# Altium schematic sheet aksv3.1.SchDoc
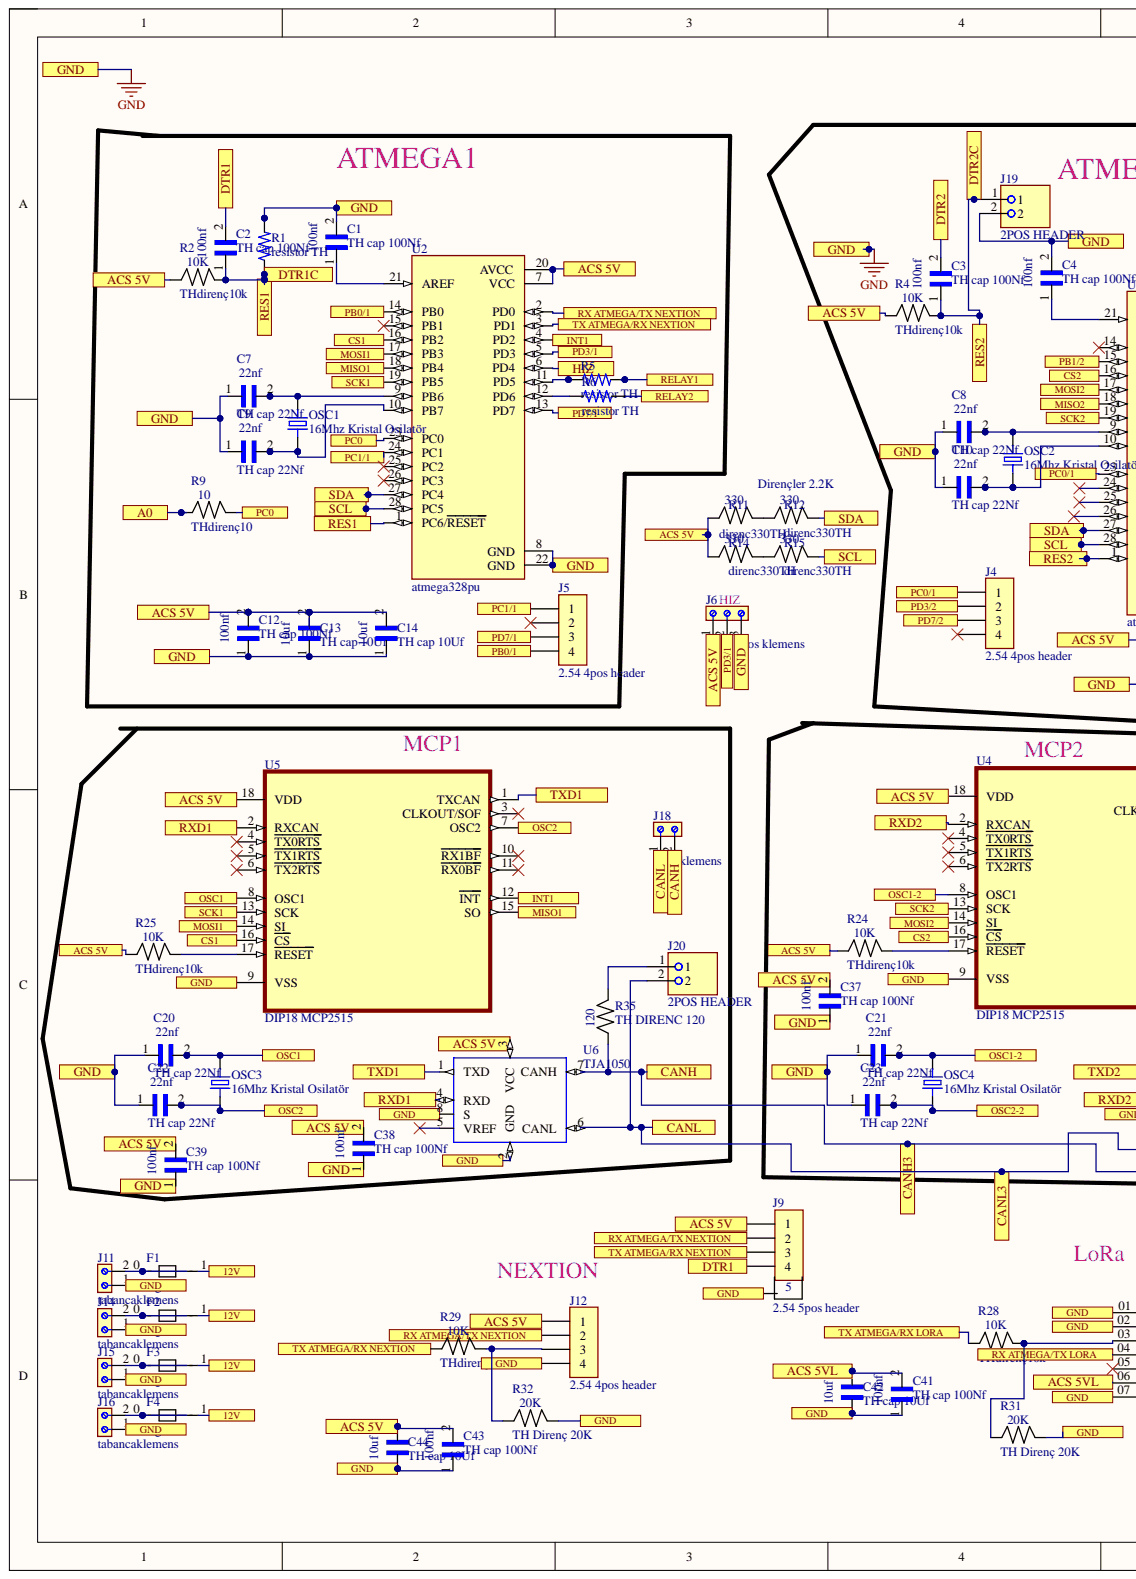
[diagram p0: aksv3.1.SchDoc, left]
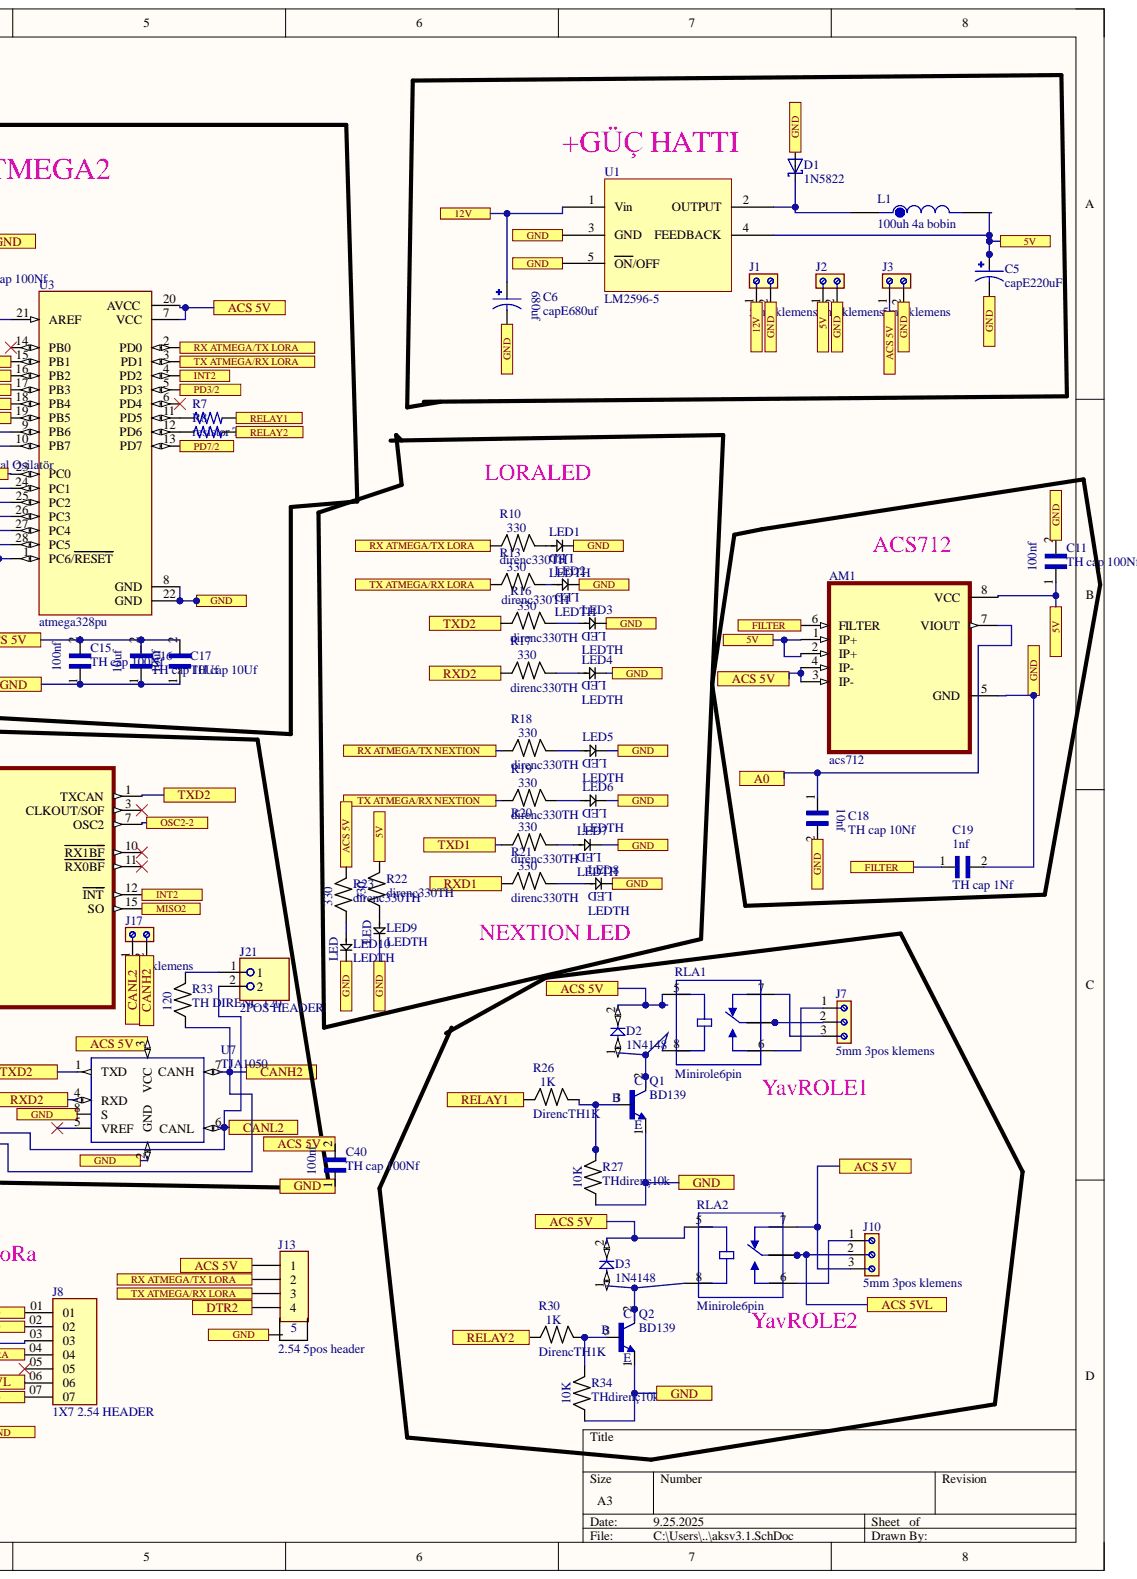
[diagram p1: aksv3.1.SchDoc, right]

## Parts
AM1  acs712  [SOIC-8 acs712]
C1  TH cap 100Nf  [Kapkucuk]
C2  TH cap 100Nf  [Kapkucuk]
C3  TH cap 100Nf  [Kapkucuk]
C4  TH cap 100Nf  [Kapkucuk]
C5  capE220uF  [elektrolitkondansatör]
C6  capE680uf  [elektrolitkondansatör]
C7  TH cap 22Nf  [Kapkucuk]
C8  TH cap 22Nf  [Kapkucuk]
C9  TH cap 22Nf  [Kapkucuk]
C10  TH cap 22Nf  [Kapkucuk]
C11  TH cap 100Nf  [Kapkucuk]
C12  TH cap 100Nf  [Kapkucuk]
C13  TH cap 10Uf  [Kapkucuk]
C14  TH cap 10Uf  [Kapkucuk]
C15  TH cap 100Nf  [Kapkucuk]
C16  TH cap 10Uf  [Kapkucuk]
C17  TH cap 10Uf  [Kapkucuk]
C18  TH cap 10Nf  [Kapkucuk]
C19  TH cap 1Nf  [Kapkucuk]
C20  TH cap 22Nf  [Kapkucuk]
C21  TH cap 22Nf  [Kapkucuk]
C22  TH cap 22Nf  [Kapkucuk]
C23  TH cap 22Nf  [Kapkucuk]
C37  TH cap 100Nf  [Kapkucuk]
C38  TH cap 100Nf  [Kapkucuk]
C39  TH cap 100Nf  [Kapkucuk]
C40  TH cap 100Nf  [Kapkucuk]
C41  TH cap 100Nf  [Kapkucuk]
C42  TH cap 10Uf  [Kapkucuk]
C43  TH cap 100Nf  [Kapkucuk]
C44  TH cap 10Uf  [Kapkucuk]
D1  1N5822  [do-201 diode]
D2  1N4148  [1N4148]
D3  1N4148  [1N4148]
F1  sigorta  [sigorta]
F2  sigorta  [sigorta]
F3  sigorta  [sigorta]
F4  sigorta  [sigorta]
J1  5mmklemens  [5mmklemens]
J2  5mmklemens  [5mmklemens]
J3  5mmklemens  [5mmklemens]
J4  2.54 4pos header  [2.54 4pos header]
J5  2.54 4pos header  [2.54 4pos header]
J6  5mm 3pos klemens  [5mm 3pos klemens]
J7  5mm 3pos klemens  [5mm 3pos klemens]
J8  1X7 2.54 HEADER  [1X7 2.54 HEADER]
J9  2.54 5pos header  [2.54 5pos header]
J10  5mm 3pos klemens  [5mm 3pos klemens]
J11  tabancaklemens  [3.81mm Kemens]
J12  2.54 4pos header  [2.54 4pos header]
J13  2.54 5pos header  [2.54 5pos header]
J14  tabancaklemens  [3.81mm Kemens]
J15  tabancaklemens  [3.81mm Kemens]
J16  tabancaklemens  [3.81mm Kemens]
J17  5mmklemens  [5mmklemens]
J18  5mmklemens  [5mmklemens]
J19  2POS HEADER  [1X2 HEADER]
J20  2POS HEADER  [1X2 HEADER]
J21  2POS HEADER  [1X2 HEADER]
L1  100uh 4a bobin  [bobin]
LED1  LEDTH  [led3mm]
LED2  LEDTH  [led3mm]
LED3  LEDTH  [led3mm]
LED4  LEDTH  [led3mm]
LED5  LEDTH  [led3mm]
LED6  LEDTH  [led3mm]
LED7  LEDTH  [led3mm]
LED8  LEDTH  [led3mm]
LED9  LEDTH  [led3mm]
LED10  LEDTH  [led3mm]
OSC1  16Mhz Kristal Osilatör  [16Mhz Kristal Osilatör]
OSC2  16Mhz Kristal Osilatör  [16Mhz Kristal Osilatör]
OSC3  16Mhz Kristal Osilatör  [16Mhz Kristal Osilatör]
OSC4  16Mhz Kristal Osilatör  [16Mhz Kristal Osilatör]
Q1  BD139  [BD139]
Q2  BD139  [BD139]
R1  resistor TH  [resistor TH]
R2  THdirenç10k  [resistor TH]
R4  THdirenç10k  [resistor TH]
R5  resistor TH  [resistor TH]
R6  resistor TH  [resistor TH]
R7  resistor TH  [resistor TH]
R8  resistor TH  [resistor TH]
R9  THdirenç10  [resistor TH]
R10  direnc330TH  [resistor TH]
R11  direnc330TH  [resistor TH]
R12  direnc330TH  [resistor TH]
R13  direnc330TH  [resistor TH]
R14  direnc330TH  [resistor TH]
R15  direnc330TH  [resistor TH]
R16  direnc330TH  [resistor TH]
R17  direnc330TH  [resistor TH]
R18  direnc330TH  [resistor TH]
R19  direnc330TH  [resistor TH]
R20  direnc330TH  [resistor TH]
R21  direnc330TH  [resistor TH]
R22  direnc330TH  [resistor TH]
R23  direnc330TH  [resistor TH]
R24  THdirenç10k  [resistor TH]
R25  THdirenç10k  [resistor TH]
R26  DirencTH1K  [resistor TH]
R27  THdirenç10k  [resistor TH]
R28  THdirenç10k  [resistor TH]
R29  THdirenç10k  [resistor TH]
R30  DirencTH1K  [resistor TH]
R31  TH Direnç 20K  [resistor TH]
R32  TH Direnç 20K  [resistor TH]
R33  TH DIRENC 120  [resistor TH]
R34  THdirenç10k  [resistor TH]
R35  TH DIRENC 120  [resistor TH]
RLA1  Minirole6pin  [minirole]
RLA2  Minirole6pin  [minirole]
U1  LM2596-5  [LM2596 SMD]
U2  atmega328pu  [atmega328pu]
U3  atmega328pu  [atmega328pu]
U4  DIP18 MCP2515  [DIP18 MCP2515]
U5  DIP18 MCP2515  [DIP18 MCP2515]
U6  TJA1050  [TJA1050]
U7  TJA1050  [TJA1050]

## Ports
5V, 12V, A0, ACS 5V, ACS 5VL, CANH, CANH2, CANH3, CANL, CANL2, CANL3, CS1, CS2, DTR1, DTR1C, DTR2, DTR2C, FILTER, GND, HIZ, INT1, INT2, MISO1, MISO2, MOSI1, MOSI2, OSC1, OSC1-2, OSC2, OSC2-2, PB0/1, PB1/2, PC0, PC0/1, PC1/1, PD3/1, PD3/2, PD7/1, PD7/2, RELAY1, RELAY2, RES1, RES2, RX ATMEGA/TX LORA, RX ATMEGA/TX NEXTION, RXD1, RXD2, SCK1, SCK2, SCL, SDA, TX ATMEGA/RX LORA, TX ATMEGA/RX NEXTION, TXD1, TXD2

## Nets
5V: AM1.1(IP+) AM1.2(IP+) AM1.8(VCC) C5.P C11.1 J2.1 L1.2 R22.1 U1.4(FEEDBACK) ; port 5V
12V: C6.P F1.1 F2.1 F3.1 F4.1 J1.1 U1.1(Vin) ; port 12V
A0: AM1.7(VIOUT) C18.1 R9.0 ; port A0
ACS 5V: AM1.3(IP-) AM1.4(IP-) C12.2 C13.2 C14.2 C15.2 C16.2 C17.2 C37.2 C38.2 C39.2 C40.2 C43.2 C44.2 D2.2 D3.2 J3.1 J6.1 J9.1 J10.3 J12.1 J13.1 R2.0 R4.0 R11.0 R14.0 R23.1 R24.0 R25.0 RLA1.5 RLA2.5 RLA2.7 U2.7(VCC) U2.20(AVCC) U3.7(VCC) U3.20(AVCC) U4.18(VDD) U5.18(VDD) U6.3(VCC) U7.3(VCC) ; port ACS 5V
ACS 5VL: C41.2 C42.2 J8.06 J10.2 RLA2.1 RLA2.12 ; port ACS 5VL
CANH: J17.2 J18.2 R33.0 R35.0 U6.7(CANH) U7.7(CANH) ; port CANH, port CANH2, port CANH3
CANL: J17.1 J18.1 J20.2 J21.2 U6.6(CANL) U7.6(CANL) ; port CANL, port CANL2, port CANL3
CS1: U2.16(PB2) U5.16(CS) ; port CS1
CS2: U3.16(PB2) U4.16(CS) ; port CS2
DTR1: C2.2 J9.4 ; port DTR1
DTR1C: C2.1 R1.0 R2.1 U2.1(PC6/RESET) ; port DTR1C, port RES1
DTR2: C3.2 J13.4 ; port DTR2
DTR2C: C3.1 J19.1 R4.1 U3.1(PC6/RESET) ; port DTR2C, port RES2
FILTER: AM1.6(FILTER) C19.1 ; port FILTER
GND: AM1.5(GND) C1.2 C4.2 C5.N C6.N C7.1 C8.1 C9.1 C10.1 C11.2 C12.1 C13.1 C14.1 C15.1 C16.1 C17.1 C18.2 C19.2 C20.1 C21.1 C22.1 C23.1 C37.1 C38.1 C39.1 C40.1 C41.1 C42.1 C43.1 C44.1 D1.A J1.2 J2.2 J3.2 J6.3 J8.01 J8.02 J8.07 J9.5 J11.1 J12.4 J13.5 J14.1 J15.1 J16.1 J19.2 LED1.1 LED2.1 LED3.1 LED4.1 LED5.1 LED6.1 LED7.1 LED8.1 LED9.1 LED10.1 Q1.1 Q2.1 R1.1 R27.0 R31.1 R32.1 R34.0 U1.3(GND) U1.5(ON/OFF) U2.8(GND) U2.22(GND) U3.8(GND) U3.22(GND) U4.9(VSS) U5.9(VSS) U6.2(GND) U6.8(S) U7.2(GND) U7.8(S) ; port GND
HIZ: U2.6(PD4) ; port HIZ
INT1: U2.4(PD2) U5.12(INT) ; port INT1
INT2: U3.4(PD2) U4.12(INT) ; port INT2
MISO1: U2.18(PB4) U5.15(SO) ; port MISO1
MISO2: U3.18(PB4) U4.15(SO) ; port MISO2
MOSI1: U2.17(PB3) U5.14(SI) ; port MOSI1
MOSI2: U3.17(PB3) U4.14(SI) ; port MOSI2
OSC1: C20.2 OSC3.2 U5.8(OSC1) ; port OSC1
OSC1-2: C21.2 OSC4.2 U4.8(OSC1) ; port OSC1-2
OSC2: C22.2 OSC3.1 U5.7(OSC2) ; port OSC2
OSC2-2: C23.2 OSC4.1 U4.7(OSC2) ; port OSC2-2
PB0/1: J5.4 U2.14(PB0) ; port PB0/1
PB1/2: U3.15(PB1) ; port PB1/2
PC0: R9.1 U2.23(PC0) ; port PC0
PC0/1: J4.1 U3.23(PC0) ; port PC0/1
PC1/1: J5.1 U2.24(PC1) ; port PC1/1
PD3/1: J6.2 U2.5(PD3) ; port PD3/1
PD3/2: J4.2 U3.5(PD3) ; port PD3/2
PD7/1: J5.3 U2.13(PD7) ; port PD7/1
PD7/2: J4.3 U3.13(PD7) ; port PD7/2
RELAY1: R5.1 R7.1 R26.0 ; port RELAY1
RELAY2: R6.1 R8.1 R30.0 ; port RELAY2
RX ATMEGA/TX LORA: J8.04 J13.2 R10.0 U3.2(PD0) ; port RX ATMEGA/TX LORA
RX ATMEGA/TX NEXTION: J9.2 J12.2 R18.0 U2.2(PD0) ; port RX ATMEGA/TX NEXTION
RXD1: R21.0 U5.2(RXCAN) U6.4(RXD) ; port RXD1
RXD2: R17.0 U4.2(RXCAN) U7.4(RXD) ; port RXD2
SCK1: U2.19(PB5) U5.13(SCK) ; port SCK1
SCK2: U3.19(PB5) U4.13(SCK) ; port SCK2
SCL: R15.1 U2.28(PC5) U3.28(PC5) ; port SCL
SDA: R12.1 U2.27(PC4) U3.27(PC4) ; port SDA
TX ATMEGA/RX LORA: J13.3 R13.0 R28.0 U3.3(PD1) ; port TX ATMEGA/RX LORA
TX ATMEGA/RX NEXTION: J9.3 R19.0 R29.0 U2.3(PD1) ; port TX ATMEGA/RX NEXTION
TXD1: R20.0 U5.1(TXCAN) U6.1(TXD) ; port TXD1
TXD2: R16.0 U4.1(TXCAN) U7.1(TXD) ; port TXD2
NetC1_1: C1.1 U2.21(AREF)
NetC4_1: C4.1 U3.21(AREF)
NetC7_2: C7.2 OSC1.2 U2.9(PB6)
NetC8_2: C8.2 OSC2.2 U3.9(PB6)
NetC9_2: C9.2 OSC1.1 U2.10(PB7)
NetC10_2: C10.2 OSC2.1 U3.10(PB7)
NetD1_C: D1.C L1.1 U1.2(OUTPUT)
NetD2_1: D2.1 Q1.2 RLA1.8
NetD3_1: D3.1 Q2.2 RLA2.8
NetF1_0: F1.0 J11.2
NetF2_0: F2.0 J14.2
NetF3_0: F3.0 J15.2
NetF4_0: F4.0 J16.2
NetJ7_1: J7.1 RLA1.6
NetJ7_2: J7.2 RLA1.1 RLA1.12
NetJ7_3: J7.3 RLA1.7
NetJ8_03: J8.03 R28.1 R31.0
NetJ10_1: J10.1 RLA2.6
NetJ12_3: J12.3 R29.1 R32.0
NetJ20_1: J20.1 R35.1
NetJ21_1: J21.1 R33.1
NetLED1_0: LED1.0 R10.1
NetLED2_0: LED2.0 R13.1
NetLED3_0: LED3.0 R16.1
NetLED4_0: LED4.0 R17.1
NetLED5_0: LED5.0 R18.1
NetLED6_0: LED6.0 R19.1
NetLED7_0: LED7.0 R20.1
NetLED8_0: LED8.0 R21.1
NetLED9_0: LED9.0 R22.0
NetLED10_0: LED10.0 R23.0
NetQ1_3: Q1.3 R26.1 R27.1
NetQ2_3: Q2.3 R30.1 R34.1
NetR5_0: R5.0 U2.11(PD5)
NetR6_0: R6.0 U2.12(PD6)
NetR7_0: R7.0 U3.11(PD5)
NetR8_0: R8.0 U3.12(PD6)
NetR11_1: R11.1 R12.0
NetR14_1: R14.1 R15.0
NetR24_1: R24.1 U4.17(RESET)
NetR25_1: R25.1 U5.17(RESET)

Not connected: J4 4; J5 2; J8 05; U2 15(PB1) 25(PC2) 26(PC3); U3 6(PD4) 14(PB0) 24(PC1) 25(PC2) 26(PC3); U4 3(CLKOUT/SOF) 4(TX0RTS) 5(TX1RTS) 6(TX2RTS) 10(RX1BF) 11(RX0BF); U5 3(CLKOUT/SOF) 4(TX0RTS) 5(TX1RTS) 6(TX2RTS) 10(RX1BF) 11(RX0BF); U6 5(VREF); U7 5(VREF)

## Library data of the parts
AM1 = acs712: Component Kind: Standard; Library Name: Copy of Parts.SchLib
C1-C4 C11 C12 C15 C37-C41 C43 = TH cap 100Nf: Component Kind: Standard; Library Name: Copy of Parts.SchLib
C5 = capE220uF: Component Kind: Standard; Library Name: Copy of Parts.SchLib
C6 = capE680uf: Component Kind: Standard; Library Name: Copy of Parts.SchLib
C7-C10 C20-C23 = TH cap 22nf: Component Kind: Standard; Library Name: Copy of Parts.SchLib
C13 C14 C16 C17 C42 C44 = TH cap 10Uf: Component Kind: Standard; Library Name: Copy of Parts.SchLib
C18 = TH cap 10Nf: Component Kind: Standard; Library Name: Copy of Parts.SchLib
C19 = TH cap 1Nf: Component Kind: Standard; Library Name: Copy of Parts.SchLib
D1 = 1N5822: Component Kind: Standard; Library Name: Copy of Parts.SchLib
D2 D3 = 1N4148: Component Kind: Standard; Library Name: Copy of Parts.SchLib
F1-F4 = sigorta: Component Kind: Standard; Library Name: Copy of Parts.SchLib
J1-J3 J17 J18 = 5mmklemens: Component Kind: Standard; Library Name: Copy of Parts.SchLib
J4 J5 J12 = 2.54 4pos header: Component Kind: Standard; Library Name: Copy of Parts.SchLib
J6 J7 J10 = 5mm 3pos klemens: Component Kind: Standard; Library Name: Copy of Parts.SchLib
J8 = 1X7 2.54 HEADER: Component Kind: Standard; Library Name: Copy of Parts.SchLib
J9 J13 = 2.54 5pos header: Component Kind: Standard; Library Name: Copy of Parts.SchLib
J11 J14-J16 = tabancaklemens: Component Kind: Standard; Library Name: Copy of Parts.SchLib
J19-J21 = 2POS HEADER: Component Kind: Standard; Library Name: Copy of Parts.SchLib
L1 = 100uh 4a bobin: Component Kind: Standard; Library Name: Copy of Parts.SchLib
LED1-LED10 = LEDTH: Component Kind: Standard; Library Name: Copy of Parts.SchLib
OSC1-OSC4 = 16Mhz Kristal Osilatör: Component Kind: Standard; Library Name: Copy of Parts.SchLib
Q1 Q2 = BD139: Component Kind: Standard; Library Name: Copy of Parts.SchLib
R1 R5-R8 = resistor TH: Component Kind: Standard; Library Name: Copy of Parts.SchLib
R2 R4 R24 R25 R27-R29 R34 = THdirenç10k: Component Kind: Standard; Library Name: Copy of Parts.SchLib
R9 = THdirenç10: Component Kind: Standard; Library Name: Copy of Parts.SchLib
R10-R23 = direnc330TH: Component Kind: Standard; Library Name: Copy of Parts.SchLib
R26 R30 = DirencTH1K: Component Kind: Standard; Library Name: Copy of Parts.SchLib
R31 R32 = TH Direnç 20K: Component Kind: Standard; Library Name: Copy of Parts.SchLib
R33 R35 = TH DIRENC 120: Component Kind: Standard; Library Name: Copy of Parts.SchLib
RLA1 RLA2 = Minirole6pin: Component Kind: Standard; Library Name: Copy of Parts.SchLib
U1 = LM2596-5: Component Kind: Standard; Library Name: Copy of Parts.SchLib
U2 U3 = atmega328pu: Component Kind: Standard; Library Name: Copy of Parts.SchLib
U4 U5 = DIP18 MCP2515: Component Kind: Standard; Library Name: Copy of Parts.SchLib
U6 U7 = TJA1050: Component Kind: Standard; Library Name: Copy of Parts.SchLib
